# Altium schematic sheet [04] - POWER SUPPLY.SchDoc("[04] - POWER SUPPLY")
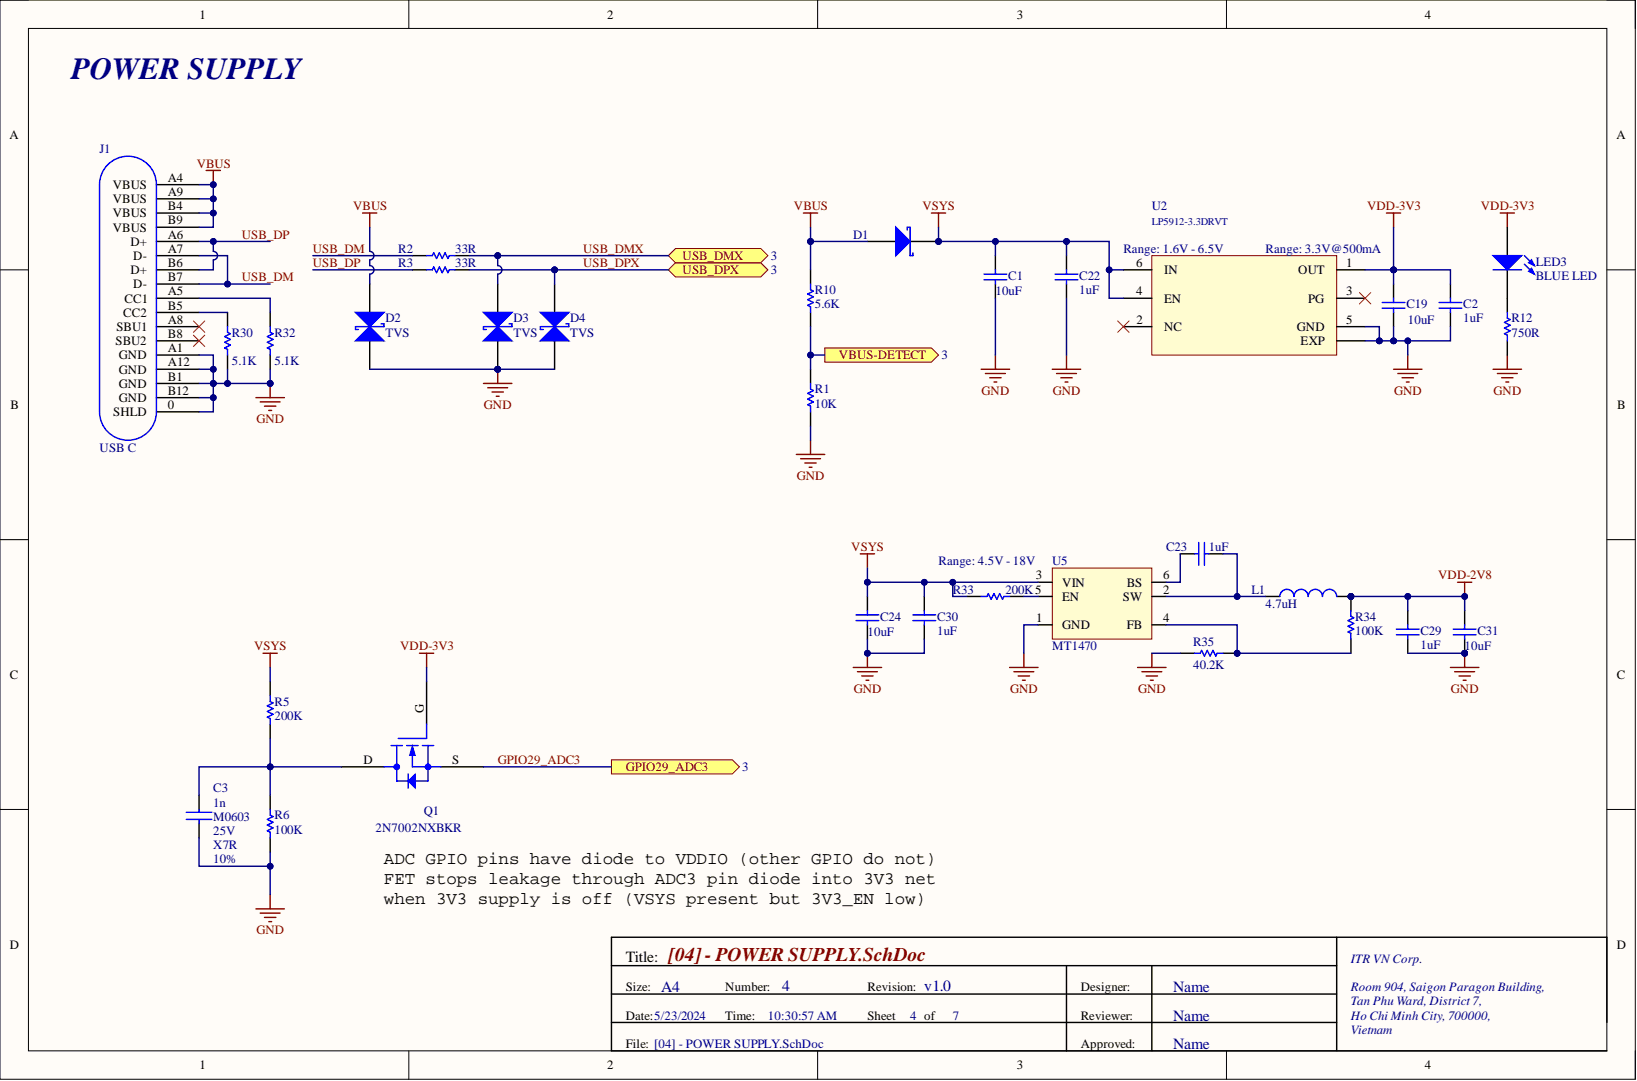
[diagram p0: [04] - POWER SUPPLY.SchDoc]

## Parts
C1  value 10uF  [CAPC2012X140_0805]  (hidden comment: Capacitor)
C2  value 1uF  [CAPC1005X55_0402]  (hidden comment: Capacitor)
C3  1n  Dielectric: X7R  Package: M0603  Tolerance: 10%  Voltage: 25V  [CAPC1005X55_0402]
C19  value 10uF  [CAPC2012X140_0805]  (hidden comment: Capacitor)
C22  value 1uF  [CAPC1005X55_0402]  (hidden comment: Capacitor)
C23  value 1uF  [CAPC1005X55_0402]  (hidden comment: Capacitor)
C24  value 10uF  [CAPC2012X140_0805]  (hidden comment: Capacitor)
C29  value 1uF  [CAPC1005X55_0402]  (hidden comment: Capacitor)
C30  value 1uF  [CAPC1005X55_0402]  (hidden comment: Capacitor)
C31  value 10uF  [CAPC2012X140_0805]  (hidden comment: Capacitor)
D1  Diode Schottky  [SS34F]  (value not drawn)
D2  TVS  [LEDSC160X80X90_2_0603]
D3  TVS  [LEDSC160X80X90_2_0603]
D4  TVS  [LEDSC160X80X90_2_0603]
J1  USB C  [USBC_16PIN]
L1  value 4.7uH  Inductance: 4.7uH  [0806]  (hidden comment: MLP2016H4R7MT0S1)
LED3  BLUE LED  [LED-0805-SideView]
Q1  2N7002NXBKR  [SOT190P250X100_3]
R1  value 10K  [RESC1005X40_0402]  (hidden comment: Resistor)
R2  value 33R  Resistance: 33R  [RESC1005X40_0402]  (hidden comment: Resistor)
R3  value 33R  Resistance: 33R  [RESC1005X40_0402]  (hidden comment: Resistor)
R5  value 200K  [RESC1005X40_0402]  (hidden comment: Resistor)
R6  value 100K  [RESC1005X40_0402]  (hidden comment: Resistor)
R10  value 5.6K  [RESC1005X40_0402]  (hidden comment: Resistor)
R12  value 750R  [RESC1005X40_0402]  (hidden comment: Resistor)
R30  value 5.1K  [RESC1005X40_0402]  (hidden comment: Resistor)
R32  value 5.1K  [RESC1005X40_0402]  (hidden comment: Resistor)
R33  value 200K  [RESC1005X40_0402]  (hidden comment: Resistor)
R34  value 100K  [RESC1005X40_0402]  (hidden comment: Resistor)
R35  value 40.2K  [RESC1005X40_0402]  (hidden comment: Resistor)
U2  LP5912-3.3DRVT  [WDFN6_2X2_P0.65]
U5  MT1470  [SOT23-6-MT1470]

## Ports
GPIO29_ADC3, USB_DMX, USB_DPX, VBUS-DETECT

## Nets
GND: C1.1 C2.1 C3.2 C19.1 C22.1 C24.1 C29.1 C30.1 C31.1 D2.1 D3.1 D4.1 J1.0(SHLD) J1.A1(GND) J1.A12(GND) J1.B1(GND) J1.B12(GND) R1.1 R6.1 R12.1 R30.1 R32.1 R35.1 U2.5(GND) U2.EXP U5.1(GND)
VBUS: D1.1 D2.2 J1.A4(VBUS) J1.A9(VBUS) J1.B4(VBUS) J1.B9(VBUS) R10.2
VDD-2V8: C29.2 C31.2 L1.2 R34.2
VDD-3V3: C2.2 C19.2 LED3.1 Q1.G U2.1(OUT)
VSYS: C1.2 C22.2 C24.2 C30.2 D1.2 R5.2 R33.2 U2.4(EN) U2.6(IN) U5.3(VIN)
GPIO29_ADC3: Q1.S ; port GPIO29_ADC3
USB_DM: J1.A7(D-) J1.B7(D-) R2.1
USB_DMX: D3.2 R2.2 ; port USB_DMX
USB_DP: J1.A6(D+) J1.B6(D+) R3.1
USB_DPX: D4.2 R3.2 ; port USB_DPX
VBUS-DETECT: R1.2 R10.1 ; port VBUS-DETECT
NetC3_1: C3.1 Q1.D R5.1 R6.2
NetC23_1: C23.1 L1.1 U5.2(SW)
NetC23_2: C23.2 U5.6(BS)
NetJ1_A5: J1.A5(CC1) R32.2
NetJ1_B5: J1.B5(CC2) R30.2
NetLED3_2: LED3.2 R12.2
NetR33_1: R33.1 U5.5(EN)
NetR34_1: R34.1 R35.2 U5.4(FB)

Not connected: J1 A8(SBU1) B8(SBU2); U2 2(NC) 3(PG)

## Library data of the parts
C1 C19 C24 C31 = Capacitor Semi: Multilayer Ceramic Capacitor, 10 uF, 16 V, ± 10%, X5R, 0805 [2012 Metric]; Manufacturer 1: Murata; Manufacturer Part Number 1: GRM21BR61C106KE15K; Material: 4241ConductorMaterial; Voltage Rating (DC): 16V; Category: Ceramic Capacitors; Component Kind: Standard; ComponentLink1Description: https://datasheet.octopart.com/GRM188R6YA106MA73D-Murata-datasheet-149523261.pdf?src-supplier=Newark; ComponentLink1URL: https://datasheet.octopart.com/GRM188R6YA106MA73D-Murata-datasheet-149523261.pdf?src-supplier=Newark; ComponentLink2Description: http://search.murata.co.jp/Ceramy/image/img/A01X/G101/ENG/GRM188R6YA106MA73-01.pdf?src-supplier=Future+Electronics; ComponentLink2URL: http://search.murata.co.jp/Ceramy/image/img/A01X/G101/ENG/GRM188R6YA106MA73-01.pdf?src-supplier=Future+Electronics; ComponentLink3Description: http://search.murata.co.jp/Ceramy/image/img/A01X/G101/ENG/GRM188R6YA106MA73-01.pdf?src-supplier=Digi-Key; ComponentLink3URL: http://search.murata.co.jp/Ceramy/image/img/A01X/G101/ENG/GRM188R6YA106MA73-01.pdf?src-supplier=Digi-Key; ComponentLink4Description: https://www.murata.com/~/media/webrenewal/support/library/catalog/products/capacitor/mlcc/c02e.ashx?la=en-us&src-supplier=Digi-Key; ComponentLink4URL: https://www.murata.com/~/media/webrenewal/support/library/catalog/products/capacitor/mlcc/c02e.ashx?la=en-us&src-supplier=Digi-Key; ComponentLink5Description: https://datasheet.octopart.com/GRM188R6YA106MA73D-Murata-datasheet-86813743.pdf?src-supplier=Future+Electronics; ComponentLink5URL: https://datasheet.octopart.com/GRM188R6YA106MA73D-Murata-datasheet-86813743.pdf?src-supplier=Future+Electronics; ComponentLink6Description: http://search.murata.co.jp/Ceramy/image/img/A01X/G101/ENG/GRM188R6YA106MA73-01.pdf?src-supplier=Mouser; ComponentLink6URL: http://search.murata.co.jp/Ceramy/image/img/A01X/G101/ENG/GRM188R6YA106MA73-01.pdf?src-supplier=Mouser; ComponentLink7Description: https://datasheet.octopart.com/GRM188R6YA106MA73D-Murata-datasheet-165579666.pdf?src-supplier=TME; ComponentLink7URL: https://datasheet.octopart.com/GRM188R6YA106MA73D-Murata-datasheet-165579666.pdf?src-supplier=TME; ComponentLink8Description: http://search.murata.co.jp/Ceramy/image/img/A01X/G101/ENG/GRM188R6YA106MA73-01.pdf?src-supplier=TTI; ComponentLink8URL: http://search.murata.co.jp/Ceramy/image/img/A01X/G101/ENG/GRM188R6YA106MA73-01.pdf?src-supplier=TTI; Library Name: Miscellaneous_Devices.SchLib; Package: 0805; Pricing 1: 1=0.18, 10=0.119, 50=0.0714, 100=0.0562, 500=0.04146, 1000=0.03433, 2500=0.03195, 5000=0.02923 (USD); RoHS: Yes; Stock 1: 1997888; Supplier 1: Digi-Key; Supplier Part Number 1: 490-6473-1-ND
  C1: ComponentLink9Description: http://www.mouser.com/ds/2/281/c02e-2905.pdf?src-supplier=Mouser; ComponentLink9URL: http://www.mouser.com/ds/2/281/c02e-2905.pdf?src-supplier=Mouser; ComponentLink10Description: http://www.farnell.com/datasheets/1955679.pdf?src-supplier=Farnell; ComponentLink10URL: http://www.farnell.com/datasheets/1955679.pdf?src-supplier=Farnell; ComponentLink11Description: http://www.farnell.com/datasheets/1955679.pdf?src-supplier=Newark; ComponentLink11URL: http://www.farnell.com/datasheets/1955679.pdf?src-supplier=Newark; ComponentLink12Description: http://psearch.en.murata.com/capacitor/product/GRM188R60J106KE47%23.pdf?src-supplier=Avnet; ComponentLink12URL: http://psearch.en.murata.com/capacitor/product/GRM188R60J106KE47%23.pdf?src-supplier=Avnet; ComponentLink13Description: http://search.murata.co.jp/Ceramy/image/img/A01X/G101/ENG/GRM188R60J106KE47-02.pdf?src-supplier=Digi-Key; ComponentLink13URL: http://search.murata.co.jp/Ceramy/image/img/A01X/G101/ENG/GRM188R60J106KE47-02.pdf?src-supplier=Digi-Key; ComponentLink14Description: http://www.farnell.com/datasheets/1955679.pdf?src-supplier=Element14; ComponentLink14URL: http://www.farnell.com/datasheets/1955679.pdf?src-supplier=Element14; ComponentLink15Description: https://datasheet.octopart.com/GRM188R60J106KE47D-Murata-datasheet-46741883.pdf?src-supplier=Element14; ComponentLink15URL: https://datasheet.octopart.com/GRM188R60J106KE47D-Murata-datasheet-46741883.pdf?src-supplier=Element14; ComponentLink16Description: https://datasheet.octopart.com/GRM188R60J106KE47D-Murata-datasheet-76796297.pdf?src-supplier=Future+Electronics; ComponentLink16URL: https://datasheet.octopart.com/GRM188R60J106KE47D-Murata-datasheet-76796297.pdf?src-supplier=Future+Electronics; ComponentLink17Description: http://www.farnell.com/datasheets/1872210.pdf?src-supplier=Farnell; ComponentLink17URL: http://www.farnell.com/datasheets/1872210.pdf?src-supplier=Farnell; ComponentLink18Description: http://www.farnell.com/datasheets/1872210.pdf?src-supplier=Newark; ComponentLink18URL: http://www.farnell.com/datasheets/1872210.pdf?src-supplier=Newark; ComponentLink19Description: https://datasheet.octopart.com/GRM188R61A106ME69D-Murata-datasheet-22122223.pdf?src-supplier=Future+Electronics; ComponentLink19URL: https://datasheet.octopart.com/GRM188R61A106ME69D-Murata-datasheet-22122223.pdf?src-supplier=Future+Electronics; ComponentLink20Description: http://search.murata.co.jp/Ceramy/image/img/A01X/G101/ENG/GRM188R61A106ME69-01.pdf?src-supplier=Digi-Key; ComponentLink20URL: http://search.murata.co.jp/Ceramy/image/img/A01X/G101/ENG/GRM188R61A106ME69-01.pdf?src-supplier=Digi-Key; ComponentLink21Description: http://www.farnell.com/datasheets/2048014.pdf?src-supplier=Element14; ComponentLink21URL: http://www.farnell.com/datasheets/2048014.pdf?src-supplier=Element14; ComponentLink22Description: https://datasheet.octopart.com/GRM188R61A106ME69D-Murata-datasheet-62317187.pdf?src-supplier=Element14; ComponentLink22URL: https://datasheet.octopart.com/GRM188R61A106ME69D-Murata-datasheet-62317187.pdf?src-supplier=Element14; ComponentLink23Description: http://www.farnell.com/datasheets/1872210.pdf?src-supplier=Element14; ComponentLink23URL: http://www.farnell.com/datasheets/1872210.pdf?src-supplier=Element14; ComponentLink24Description: https://datasheet.octopart.com/GRM188R61A106ME69D-Murata-datasheet-165579666.pdf?src-supplier=TME; ComponentLink24URL: https://datasheet.octopart.com/GRM188R61A106ME69D-Murata-datasheet-165579666.pdf?src-supplier=TME; ComponentLink25Description: https://datasheet.ciiva.com/26822/getdatasheetpartid-31687-26822939.pdf?src-supplier=Verical; ComponentLink25URL: https://datasheet.ciiva.com/26822/getdatasheetpartid-31687-26822939.pdf?src-supplier=Verical; ComponentLink26Description: https://datasheet.ciiva.com/6270/partnumbering-e-01-6270407.pdf?src-supplier=Digi-Key; ComponentLink26URL: https://datasheet.ciiva.com/6270/partnumbering-e-01-6270407.pdf?src-supplier=Digi-Key; ComponentLink27Description: https://datasheet.ciiva.com/6270/partnumbering-e-01-6270410.pdf?src-supplier=Digi-Key; ComponentLink27URL: https://datasheet.ciiva.com/6270/partnumbering-e-01-6270410.pdf?src-supplier=Digi-Key; ComponentLink28Description: https://datasheet.ciiva.com/6270/partnumbering-e-01-6270412.pdf?src-supplier=Digi-Key; ComponentLink28URL: https://datasheet.ciiva.com/6270/partnumbering-e-01-6270412.pdf?src-supplier=Digi-Key; ComponentLink29Description: https://datasheet.ciiva.com/5414/product-5414934.pdf?src-supplier=Mouser; ComponentLink29URL: https://datasheet.ciiva.com/5414/product-5414934.pdf?src-supplier=Mouser
  C19: ComponentLink9Description: http://www.farnell.com/datasheets/1872210.pdf?src-supplier=Farnell; ComponentLink9URL: http://www.farnell.com/datasheets/1872210.pdf?src-supplier=Farnell; ComponentLink10Description: http://www.mouser.com/ds/2/281/c02e-2905.pdf?src-supplier=Mouser; ComponentLink10URL: http://www.mouser.com/ds/2/281/c02e-2905.pdf?src-supplier=Mouser; ComponentLink11Description: http://www.farnell.com/datasheets/1872210.pdf?src-supplier=Newark; ComponentLink11URL: http://www.farnell.com/datasheets/1872210.pdf?src-supplier=Newark; ComponentLink12Description: https://datasheet.octopart.com/GRM188R61A106ME69D-Murata-datasheet-22122223.pdf?src-supplier=Future+Electronics; ComponentLink12URL: https://datasheet.octopart.com/GRM188R61A106ME69D-Murata-datasheet-22122223.pdf?src-supplier=Future+Electronics; ComponentLink13Description: http://search.murata.co.jp/Ceramy/image/img/A01X/G101/ENG/GRM188R61A106ME69-01.pdf?src-supplier=Digi-Key; ComponentLink13URL: http://search.murata.co.jp/Ceramy/image/img/A01X/G101/ENG/GRM188R61A106ME69-01.pdf?src-supplier=Digi-Key; ComponentLink14Description: http://www.farnell.com/datasheets/2048014.pdf?src-supplier=Element14; ComponentLink14URL: http://www.farnell.com/datasheets/2048014.pdf?src-supplier=Element14; ComponentLink15Description: https://datasheet.octopart.com/GRM188R61A106ME69D-Murata-datasheet-62317187.pdf?src-supplier=Element14; ComponentLink15URL: https://datasheet.octopart.com/GRM188R61A106ME69D-Murata-datasheet-62317187.pdf?src-supplier=Element14; ComponentLink16Description: http://www.farnell.com/datasheets/1872210.pdf?src-supplier=Element14; ComponentLink16URL: http://www.farnell.com/datasheets/1872210.pdf?src-supplier=Element14; ComponentLink17Description: https://datasheet.octopart.com/GRM188R61A106ME69D-Murata-datasheet-165579666.pdf?src-supplier=TME; ComponentLink17URL: https://datasheet.octopart.com/GRM188R61A106ME69D-Murata-datasheet-165579666.pdf?src-supplier=TME; ComponentLink18Description: https://datasheet.ciiva.com/26822/getdatasheetpartid-31687-26822939.pdf?src-supplier=Verical; ComponentLink18URL: https://datasheet.ciiva.com/26822/getdatasheetpartid-31687-26822939.pdf?src-supplier=Verical; ComponentLink19Description: https://datasheet.ciiva.com/6270/partnumbering-e-01-6270407.pdf?src-supplier=Digi-Key; ComponentLink19URL: https://datasheet.ciiva.com/6270/partnumbering-e-01-6270407.pdf?src-supplier=Digi-Key; ComponentLink20Description: https://datasheet.ciiva.com/6270/partnumbering-e-01-6270410.pdf?src-supplier=Digi-Key; ComponentLink20URL: https://datasheet.ciiva.com/6270/partnumbering-e-01-6270410.pdf?src-supplier=Digi-Key; ComponentLink21Description: https://datasheet.ciiva.com/6270/partnumbering-e-01-6270412.pdf?src-supplier=Digi-Key; ComponentLink21URL: https://datasheet.ciiva.com/6270/partnumbering-e-01-6270412.pdf?src-supplier=Digi-Key; ComponentLink22Description: https://datasheet.ciiva.com/5414/product-5414934.pdf?src-supplier=Mouser; ComponentLink22URL: https://datasheet.ciiva.com/5414/product-5414934.pdf?src-supplier=Mouser
  C24 C31: ComponentLink9Description: https://datasheet.ciiva.com/26822/getdatasheetpartid-31687-26822939.pdf?src-supplier=Verical; ComponentLink9URL: https://datasheet.ciiva.com/26822/getdatasheetpartid-31687-26822939.pdf?src-supplier=Verical; ComponentLink10Description: https://datasheet.ciiva.com/6270/partnumbering-e-01-6270407.pdf?src-supplier=Digi-Key; ComponentLink10URL: https://datasheet.ciiva.com/6270/partnumbering-e-01-6270407.pdf?src-supplier=Digi-Key; ComponentLink11Description: https://datasheet.ciiva.com/6270/partnumbering-e-01-6270410.pdf?src-supplier=Digi-Key; ComponentLink11URL: https://datasheet.ciiva.com/6270/partnumbering-e-01-6270410.pdf?src-supplier=Digi-Key; ComponentLink12Description: https://datasheet.ciiva.com/6270/partnumbering-e-01-6270412.pdf?src-supplier=Digi-Key; ComponentLink12URL: https://datasheet.ciiva.com/6270/partnumbering-e-01-6270412.pdf?src-supplier=Digi-Key; ComponentLink13Description: https://datasheet.ciiva.com/5414/product-5414934.pdf?src-supplier=Mouser; ComponentLink13URL: https://datasheet.ciiva.com/5414/product-5414934.pdf?src-supplier=Mouser
C2 C22 C23 C29 C30 = Capacitor Semi: 0402 16 V 1 uF X5R ±20% Tolerance SMT Multilayer Ceramic Capacitor; Manufacturer 1: Murata; Manufacturer Part Number 1: GRM155R61C105MA12D; Symbol status: Released; Category: Ceramic Capacitors; Component Kind: Standard; ComponentLink1Description: http://www.mouser.com/ds/2/281/c02e-2905.pdf?src-supplier=Mouser; ComponentLink1URL: http://www.mouser.com/ds/2/281/c02e-2905.pdf?src-supplier=Mouser; ComponentLink2Description: http://search.murata.co.jp/Ceramy/image/img/A01X/G101/ENG/GRM155R61C105MA12-01.pdf?src-supplier=Digi-Key; ComponentLink2URL: http://search.murata.co.jp/Ceramy/image/img/A01X/G101/ENG/GRM155R61C105MA12-01.pdf?src-supplier=Digi-Key; ComponentLink3Description: https://search.murata.co.jp/Ceramy/image/img/A01X/G101/ENG/GRM155R61C105MA12-01.pdf?src-supplier=Digi-Key; ComponentLink3URL: https://search.murata.co.jp/Ceramy/image/img/A01X/G101/ENG/GRM155R61C105MA12-01.pdf?src-supplier=Digi-Key; ComponentLink4Description: https://datasheet.octopart.com/GRM155R61C105MA12D-Murata-datasheet-22122223.pdf?src-supplier=Future+Electronics; ComponentLink4URL: https://datasheet.octopart.com/GRM155R61C105MA12D-Murata-datasheet-22122223.pdf?src-supplier=Future+Electronics; ComponentLink5Description: https://datasheet.octopart.com/GRM155R61C105MA12D-Murata-datasheet-149522996.pdf?src-supplier=Newark; ComponentLink5URL: https://datasheet.octopart.com/GRM155R61C105MA12D-Murata-datasheet-149522996.pdf?src-supplier=Newark; Library Name: Miscellaneous_Devices.SchLib; Pricing 1: 1=0.1, 10=0.031, 50=0.017, 100=0.0138, 500=0.00988, 1000=0.00776, 2500=0.00705, 5000=0.00649 (USD); RoHS: Yes; Stock 1: 217243; Supplier 1: Digi-Key; Supplier 2: LCSC.com; Supplier Part Number 1: 490-14599-1-ND; Supplier Part Number 2: C181017
C3 = CAP_MLCC: Multilayer Ceramic Capacitor, 0.001 uF, 16 V, -20, +80%, Y5V, 0402 [1005 Metric]; Manufacturer 1: Yageo; Manufacturer Part Number 1: CC0402MRX7R9BB102; Capacitance: 1nF; Voltage Rating: 50V; Case Code (Imperial): 0402; Case Code (Metric): 1005; Case/Package: 0402; Category: Ceramic Capacitors; Component Kind: Standard; ComponentLink1Description: https://datasheet.ciiva.com/176/mlccorderingcode-176352.pdf?src-supplier=Digi-Key; ComponentLink1URL: https://datasheet.ciiva.com/176/mlccorderingcode-176352.pdf?src-supplier=Digi-Key; ComponentLink2Description: https://datasheet.ciiva.com/176/mlccorderingcode-176351.pdf?src-supplier=Digi-Key; ComponentLink2URL: https://datasheet.ciiva.com/176/mlccorderingcode-176351.pdf?src-supplier=Digi-Key; ComponentLink3Description: https://datasheet.ciiva.com/176/mlccorderingcode-176353.pdf?src-supplier=Digi-Key; ComponentLink3URL: https://datasheet.ciiva.com/176/mlccorderingcode-176353.pdf?src-supplier=Digi-Key; ComponentLink4Description: https://datasheet.ciiva.com/13211/upy-gp-np0-16v-to-50v-8-13211094.pdf?src-supplier=Mouser; ComponentLink4URL: https://datasheet.ciiva.com/13211/upy-gp-np0-16v-to-50v-8-13211094.pdf?src-supplier=Mouser; Halogen Free: Halogen Free; Lead Free: Lead Free; Length: 0.039inch; Max Operating Temperature: 125°C; Min Operating Temperature: -55°C; Mount: Surface Mount; Number of Pins: 2; Package Quantity: 1; Packaging: Tape and Reel; Pricing 1: 1=0.1, 10=0.016, 50=0.0088, 100=0.0071, 500=0.0051, 1000=0.004, 2500=0.00364, 5000=0.00335 (USD); RoHS: Yes; RoHS Compliant: Yes; Series: CC; Stock 1: 100467; Supplier 1: Digi-Key; Supplier 2: LCSC.com; Supplier Part Number 1: 311-1414-1-ND; Supplier Part Number 2: C527029; Thickness: 0.022inch; Voltage Rating (DC): 50V; Width: 0.02inch
D1 = Diode Schottley: Schottky Diodes & Rectifiers Schottky Rectifier; Manufacturer Part Number 1: B140AF-13; Average Rectified Current: 1A; Max Reverse Voltage (DC): 40V; Category: Rectifier Diodes; Component Kind: Standard; ComponentLink1Description: http://www.farnell.com/datasheets/2263976.pdf?src-supplier=Farnell; ComponentLink1URL: http://www.farnell.com/datasheets/2263976.pdf?src-supplier=Farnell; ComponentLink2Description: https://www.diodes.com/assets/Datasheets/B340AF_B345AF.pdf?src-supplier=Digi-Key; ComponentLink2URL: https://www.diodes.com/assets/Datasheets/B340AF_B345AF.pdf?src-supplier=Digi-Key; ComponentLink3Description: https://www.diodes.com/assets/Datasheets/B120AF-B140AF.pdf?src-supplier=Digi-Key; ComponentLink3URL: https://www.diodes.com/assets/Datasheets/B120AF-B140AF.pdf?src-supplier=Digi-Key; Library Name: Miscellaneous_Devices.SchLib; Manufacturer 1: Diodes; Mount: Surface Mount; Packaging: Cut Tape; Pricing 1: 1=0.34, 10=0.236, 100=0.119, 500=0.09706, 1000=0.07201, 2000=0.06059, 5000=0.05792 (USD); RoHS: Yes; RoHS Compliant: Yes; Series: -; Stock 1: 9845; Supplier 1: Digi-Key; Supplier 2: LCSC.com; Supplier Part Number 1: 31-B140AF-13CT-ND; Supplier Part Number 2: C172878
D2-D4 = TVS Diode: Symbol status: Doing; Component Kind: Standard; Description: TVS Diode; Library Name: Miscellaneous_Devices.SchLib
J1 = USB type C: USB type 16 pin; Component Kind: Standard; Library Name: Miscellaneous_Devices.SchLib; Manufacturer: N/A; Manufacturer Part Number: N/A; Notices: https://www.tcac.com.tw/usb3.1/T50E7312XXXX0ET.pdf; Supplier 1: banlinhkien.vn; Supplier Part Number 1: https://banlinhkien.vn/goods-11481-usb-3-1-type-c-loai-cai-16p-han-dan.html
L1 = MLP2016H4R7MT0S1: FIXED IND 4.7UH 1.1A 200MOHM SMD; Manufacturer 1: TDK; Core Material: Ferrite; DC Resistance (DCR): 200mR; Shielding: Shielded; Symbol status: Released; Test Frequency: 2MHz; Tolerance: 20%; Case Code (Imperial): 0806; Case Code (Metric): 2016; Case/Package: 0806; Category: Fixed Inductors; Component Kind: Standard; ComponentLink1Description: https://datasheet.ciiva.com/29697/inductor-commercial-power-mlp-en-29697690.pdf?src-supplier=Digi-Key; ComponentLink1URL: https://datasheet.ciiva.com/29697/inductor-commercial-power-mlp-en-29697690.pdf?src-supplier=Digi-Key; ComponentLink2Description: https://datasheet.ciiva.com/29697/inductor-commercial-power-mlp-en-29697689.pdf?src-supplier=Digi-Key; ComponentLink2URL: https://datasheet.ciiva.com/29697/inductor-commercial-power-mlp-en-29697689.pdf?src-supplier=Digi-Key; ComponentLink3Description: https://datasheet.ciiva.com/29697/inductor-commercial-power-mlp-en-29697691.pdf?src-supplier=Digi-Key; ComponentLink3URL: https://datasheet.ciiva.com/29697/inductor-commercial-power-mlp-en-29697691.pdf?src-supplier=Digi-Key; Lead Free: Lead Free; Manufacturer Part Number 1: MLP2016H4R7MT0S1; Max Operating Temperature: 125°C; Min Operating Temperature: -40°C; Mount: Surface Mount; Packaging: Cut Tape; Pricing 1: 1=0.33, 10=0.294, 25=0.2572, 50=0.2206, 100=0.2022, 250=0.18384, 500=0.1728, 1000=0.13235 (USD); RoHS: Yes; RoHS Compliant: Yes; Series: MLP; Stock 1: 21924; Supplier 1: Digi-Key; Supplier Part Number 1: 445-15726-1-ND; Library Name: BLOOD PRESSURE.SchLib
LED3 = LED-Side: LED GREEN CLEAR SMD R/A / LED for Side looking special for LCD backlight; Manufacturer 1: Vishay Lite-On; Manufacturer Part Number 1: LTST-S220GKT; Dominant Wavelength: 569nm; Forward Current: 30mA; Forward Voltage: 2.1V; Lens Color: Colorless; Lens Style: Diffused,Colorless,Rectangular,Clear; Luminous Intensity: 10mcd; Number of Elements: 1; Power Dissipation: 100mW; Reverse Voltage: 5V; Test Current: 20mA; Viewing Angle: 130°; Wavelength: 565nm; Case Code (Imperial): 0805; Case Code (Metric): 2012; Case/Package: 0805; Category: LEDs; Color: Green; Component Kind: Standard; ComponentLink1Description: https://datasheet.ciiva.com/2110/ltst-s220gkt-2110016.pdf?src-supplier=Digi-Key; ComponentLink1URL: https://datasheet.ciiva.com/2110/ltst-s220gkt-2110016.pdf?src-supplier=Digi-Key; ComponentLink2Description: https://datasheet.ciiva.com/15118/getdatasheetpartid-221136-15118117.pdf?src-supplier=Verical; ComponentLink2URL: https://datasheet.ciiva.com/15118/getdatasheetpartid-221136-15118117.pdf?src-supplier=Verical; ComponentLink3Description: https://datasheet.ciiva.com/11775/0900766b80dc0b6e-11775442.pdf?src-supplier=Rs; ComponentLink3URL: https://datasheet.ciiva.com/11775/0900766b80dc0b6e-11775442.pdf?src-supplier=Rs; ComponentLink4Description: https://datasheet.ciiva.com/2110/ltst-s220gkt-2110017.pdf?src-supplier=Digi-Key; ComponentLink4URL: https://datasheet.ciiva.com/2110/ltst-s220gkt-2110017.pdf?src-supplier=Digi-Key; ComponentLink5Description: https://datasheet.ciiva.com/16444/ltst-s220gkt-16444773.pdf?src-supplier=Future+Electronics; ComponentLink5URL: https://datasheet.ciiva.com/16444/ltst-s220gkt-16444773.pdf?src-supplier=Future+Electronics; Depth: 0.6mm; Height: 0.6mm; Illumination Color: Green; Lead Free: Lead Free; Length: 2.1mm; Lens Transparency: Clear; Library Name: Pico Camera.SchLib; Max Operating Temperature: 85°C; Min Operating Temperature: -55°C; Mount: Surface Mount; Number of LEDs: 1; Number of Pins: 2; Package Quantity: 1; Packaging: Tape and Reel; Power Rating: 100mW; Pricing 1: 1=0.25, 10=0.134, 100=0.0624, 500=0.05756, 1000=0.0451, 2000=0.04414 (USD); Radiation Hardening: No; RoHS: Yes; RoHS Compliant: Yes; Stock 1: 274681; Supplier 1: Digi-Key; Supplier Part Number 1: 160-1218-1-ND; Width: 1mm
Q1 = MOSFET_N: Transistor Mosfet N-ch 60V 0.33A 3-PIN SOT-23 T/r; Manufacturer 1: Nexperia; Component Kind: Standard; Manufacturer Part Number 1: 2N7002NXBKR; Pricing 1: 1=0.21, 10=0.149, 100=0.0805, 500=0.06326, 1000=0.04394 (USD); RoHS: No; Stock 1: 35523; Supplier 1: Digi-Key; Supplier 2: LCSC.com; Supplier Part Number 1: 1727-8642-1-ND; Supplier Part Number 2: C551417
R1 = Res1: RES SMD 10K OHM 5% 1/10W 0402; Manufacturer 1: Panasonic; Manufacturer Part Number 1: ERJ-2GEJ103X; BOM Type: A; Max Power Dissipation: 100mW; Resistance: 10kR; Temperature Coefficient: 200ppm/°C; Voltage Rating: 50V; Case Code (Imperial): 0402; Case Code (Metric): 1005; Case/Package: 0402; Category: Chip SMD Resistors; Component Kind: Standard; ComponentLink1Description: https://datasheet.ciiva.com/11821/0900766b80f806dd-11821170.pdf?src-supplier=RSComponents; ComponentLink1URL: https://datasheet.ciiva.com/11821/0900766b80f806dd-11821170.pdf?src-supplier=RSComponents; ComponentLink2Description: https://datasheet.ciiva.com/27055/1935721-27055112.pdf?src-supplier=Jameco; ComponentLink2URL: https://datasheet.ciiva.com/27055/1935721-27055112.pdf?src-supplier=Jameco; ComponentLink3Description: https://datasheet.ciiva.com/17212/aoa0000ce1-17212101.pdf?src-supplier=Avnet+Europe; ComponentLink3URL: https://datasheet.ciiva.com/17212/aoa0000ce1-17212101.pdf?src-supplier=Avnet+Europe; ComponentLink4Description: https://datasheet.ciiva.com/46371/1678796-46371910.pdf?src-supplier=Element14; ComponentLink4URL: https://datasheet.ciiva.com/46371/1678796-46371910.pdf?src-supplier=Element14; ComponentLink5Description: https://datasheet.ciiva.com/24070/aoa0000ce1-37422-24070679.pdf?src-supplier=Mouser; ComponentLink5URL: https://datasheet.ciiva.com/24070/aoa0000ce1-37422-24070679.pdf?src-supplier=Mouser; ComponentLink6Description: https://datasheet.ciiva.com/43460/1678796-43460391.pdf?src-supplier=Farnell; ComponentLink6URL: https://datasheet.ciiva.com/43460/1678796-43460391.pdf?src-supplier=Farnell; ComponentLink7Description: https://datasheet.ciiva.com/16774/70260739-16774504.pdf?src-supplier=Allied+Electronics; ComponentLink7URL: https://datasheet.ciiva.com/16774/70260739-16774504.pdf?src-supplier=Allied+Electronics; Contact Plating: Tin; Depth: 0.5mm; Features: Automotive AEC-Q200; Height: 0.35mm; LatestRevisionDate: 17-Jul-2002; LatestRevisionNote: Re-released for DXP Platform.; Lead Free: Lead Free; Length: 1mm; Library Name: Miscellaneous Devices.IntLib; Max Operating Temperature: 155°C; Military Standard: Not; Min Operating Temperature: -55°C; Mount: Surface Mount; Number of Terminations: 2; Package Quantity: 1; PackageDescription: Axial Device, Thru-Hole; 2 Leads; 0.3 in Pin Spacing; PackageReference: AXIAL-0.3; Packaging: Cut Tape; Power Rating: 100mW; Pricing 1: 1=0.10000, 10=0.01900, 100=0.00770, 1000=0.00345, 2500=0.00299, 5000=0.00247 (USD); Published: 8-Jun-2000; Radiation Hardening: No; REACH SVHC: No SVHC; Resistor Type: Thick Film; RoHS: Yes; Signal Integrity: Res; Simulation: RESISTOR; Stock 1: 2048955; Supplier 1: Digi-Key; Supplier 2: LCSC.com; Supplier Part Number 1: P10KJCT-ND; Supplier Part Number 2: C278577; Termination: SMD/SMT; Tolerance: 5%; Voltage Rating (DC): 50V; Width: 0.02inch; Publisher: Altium Limited
R2 R3 = R_0402: SMD Chip Resistor, 33 Ohm, ± 1%, 63 mW, 0402 [1005 Metric], Thick Film, General Purpose; Manufacturer 1: Yageo; Manufacturer Part Number 1: RC0402FR-0733RL; Max Power Dissipation: 63mW; Power Rating: 62.5mW; Symbol status: Released; Temperature Coefficient: 100ppm/°C; Voltage Rating: 50V; Case Code (Imperial): 0402; Case Code (Metric): 1005; Case/Package: 0402; Category: Chip SMD Resistors; Component Kind: Standard; ComponentLink1Description: https://datasheet.ciiva.com/11819/0900766b80c66297-11819487.pdf?src-supplier=Rs; ComponentLink1URL: https://datasheet.ciiva.com/11819/0900766b80c66297-11819487.pdf?src-supplier=Rs; ComponentLink2Description: https://datasheet.ciiva.com/24070/pyu-rc0402-51-rohs-l-6-r-8721-24070077.pdf?src-supplier=Mouser; ComponentLink2URL: https://datasheet.ciiva.com/24070/pyu-rc0402-51-rohs-l-6-r-8721-24070077.pdf?src-supplier=Mouser; ComponentLink3Description: https://datasheet.ciiva.com/7849/1018594-7849967.pdf?src-supplier=Element14; ComponentLink3URL: https://datasheet.ciiva.com/7849/1018594-7849967.pdf?src-supplier=Element14; ComponentLink4Description: https://datasheet.ciiva.com/7849/1018594-7849966.pdf?src-supplier=Element14; ComponentLink4URL: https://datasheet.ciiva.com/7849/1018594-7849966.pdf?src-supplier=Element14; ComponentLink5Description: https://datasheet.ciiva.com/15189/getdatasheetpartid-329253-15189431.pdf?src-supplier=Verical; ComponentLink5URL: https://datasheet.ciiva.com/15189/getdatasheetpartid-329253-15189431.pdf?src-supplier=Verical; ComponentLink6Description: https://datasheet.ciiva.com/17070/pyu-rc0402-51-rohs-l-6-r-17070335.pdf?src-supplier=Future+Electronics; ComponentLink6URL: https://datasheet.ciiva.com/17070/pyu-rc0402-51-rohs-l-6-r-17070335.pdf?src-supplier=Future+Electronics; Construction: Rectangular; Depth: 0.5mm; Features: Moisture Resistant; Height: 0.35mm; Lead Free: Lead Free; Length: 1mm; Library Name: Miscellaneous_Devices.SchLib; Max Operating Temperature: 155°C; Military Standard: Not; Min Operating Temperature: -55°C; Number of Pins: 2; Number of Terminations: 2; Package Quantity: 10000; Packaging: Tape and Reel; Pricing 1: 1=0.1, 10=0.014, 50=0.0084, 100=0.007, 500=0.00464, 1000=0.00396, 5000=0.00282 (USD); Reach SVHC Compliant: Unknown; Resistance Tolerance: 1%; Resistor Type: Thick Film; RoHS: Yes; RoHS Compliant: Yes; Series: RC; Size Code: 0402; Stock 1: 10443232; Supplier 1: Digi-Key; Supplier 2: LCSC.com; Supplier Part Number 1: 311-33.0LRCT-ND; Supplier Part Number 2: C138002; Technology: THICK FILM; Termination: SMD/SMT; Tolerance: 1%; Voltage Rating (AC): 50V; Voltage Rating (DC): 50V; Width: 0.5mm
R5 R33 = Resistor: SMD Chip Resistor, 200 kOhm, ± 1%, 63 mW, 0402 [1005 Metric], Thick Film, General Purpose; Manufacturer 1: Yageo; Manufacturer Part Number 1: RC0402FR-07200KL; Max Power Dissipation: 63mW; Resistance: 200kR; Temperature Coefficient: 100ppm/°C; Voltage Rating: 50V; Case Code (Imperial): 0402; Case Code (Metric): 1005; Case/Package: 0402; Category: Chip SMD Resistors; Component Kind: Standard; ComponentLink1Description: https://datasheet.ciiva.com/7849/1018594-7849899.pdf?src-supplier=Element14; ComponentLink1URL: https://datasheet.ciiva.com/7849/1018594-7849899.pdf?src-supplier=Element14; ComponentLink2Description: https://datasheet.ciiva.com/7849/1018594-7849898.pdf?src-supplier=Element14; ComponentLink2URL: https://datasheet.ciiva.com/7849/1018594-7849898.pdf?src-supplier=Element14; ComponentLink3Description: https://datasheet.ciiva.com/7983/1018594-7983509.pdf?src-supplier=Element14; ComponentLink3URL: https://datasheet.ciiva.com/7983/1018594-7983509.pdf?src-supplier=Element14; ComponentLink4Description: https://datasheet.ciiva.com/2553/pyu-r-int-thick-7-2553231.pdf?src-supplier=Digi-Key; ComponentLink4URL: https://datasheet.ciiva.com/2553/pyu-r-int-thick-7-2553231.pdf?src-supplier=Digi-Key; ComponentLink5Description: https://datasheet.ciiva.com/2553/pyu-r-int-thick-7-2553232.pdf?src-supplier=Digi-Key; ComponentLink5URL: https://datasheet.ciiva.com/2553/pyu-r-int-thick-7-2553232.pdf?src-supplier=Digi-Key; ComponentLink6Description: https://datasheet.ciiva.com/2553/pyu-r-int-thick-7-2553230.pdf?src-supplier=Digi-Key; ComponentLink6URL: https://datasheet.ciiva.com/2553/pyu-r-int-thick-7-2553230.pdf?src-supplier=Digi-Key; ComponentLink7Description: https://datasheet.ciiva.com/5562/pyu-rc0402-51-rohs-l-6-r-8721-5562539.pdf?src-supplier=Mouser; ComponentLink7URL: https://datasheet.ciiva.com/5562/pyu-rc0402-51-rohs-l-6-r-8721-5562539.pdf?src-supplier=Mouser; ComponentLink8Description: https://datasheet.ciiva.com/16804/pyu-rc0402-51-rohs-l-6-r-16804406.pdf?src-supplier=Future+Electronics; ComponentLink8URL: https://datasheet.ciiva.com/16804/pyu-rc0402-51-rohs-l-6-r-16804406.pdf?src-supplier=Future+Electronics; ComponentLink9Description: https://datasheet.ciiva.com/15189/getdatasheetpartid-331152-15189383.pdf?src-supplier=Verical; ComponentLink9URL: https://datasheet.ciiva.com/15189/getdatasheetpartid-331152-15189383.pdf?src-supplier=Verical; ComponentLink10Description: https://datasheet.ciiva.com/7849/1018594-7849897.pdf?src-supplier=Element14; ComponentLink10URL: https://datasheet.ciiva.com/7849/1018594-7849897.pdf?src-supplier=Element14; ComponentLink11Description: https://datasheet.ciiva.com/7849/1018594-7849896.pdf?src-supplier=Element14; ComponentLink11URL: https://datasheet.ciiva.com/7849/1018594-7849896.pdf?src-supplier=Element14; ComponentLink12Description: https://datasheet.ciiva.com/46394/1018594-46394953.pdf?src-supplier=Element14; ComponentLink12URL: https://datasheet.ciiva.com/46394/1018594-46394953.pdf?src-supplier=Element14; ComponentLink13Description: https://datasheet.ciiva.com/2453/pyu-r-int-thick-7-2453557.pdf?src-supplier=Digi-Key; ComponentLink13URL: https://datasheet.ciiva.com/2453/pyu-r-int-thick-7-2453557.pdf?src-supplier=Digi-Key; ComponentLink14Description: https://datasheet.ciiva.com/2453/pyu-r-int-thick-7-2453558.pdf?src-supplier=Digi-Key; ComponentLink14URL: https://datasheet.ciiva.com/2453/pyu-r-int-thick-7-2453558.pdf?src-supplier=Digi-Key; ComponentLink15Description: https://datasheet.ciiva.com/2453/pyu-r-int-thick-7-2453556.pdf?src-supplier=Digi-Key; ComponentLink15URL: https://datasheet.ciiva.com/2453/pyu-r-int-thick-7-2453556.pdf?src-supplier=Digi-Key; ComponentLink16Description: https://datasheet.ciiva.com/12667/pyu-rc0402-51-rohs-l-6-r-12667911.pdf?src-supplier=Mouser; ComponentLink16URL: https://datasheet.ciiva.com/12667/pyu-rc0402-51-rohs-l-6-r-12667911.pdf?src-supplier=Mouser; ComponentLink17Description: https://datasheet.ciiva.com/15189/getdatasheetpartid-323720-15189381.pdf?src-supplier=Verical; ComponentLink17URL: https://datasheet.ciiva.com/15189/getdatasheetpartid-323720-15189381.pdf?src-supplier=Verical; ComponentLink18Description: https://datasheet.ciiva.com/16740/pyu-rc0402-51-rohs-l-6-r-16740636.pdf?src-supplier=Future+Electronics; ComponentLink18URL: https://datasheet.ciiva.com/16740/pyu-rc0402-51-rohs-l-6-r-16740636.pdf?src-supplier=Future+Electronics; Construction: Rectangular; Depth: 0.5mm; Features: Moisture Resistant; Height: 0.016inch; Lead Free: Lead Free; Length: 0.039inch; Library Name: Miscellaneous_Devices.SchLib; Max Operating Temperature: 155°C; Military Standard: Not; Min Operating Temperature: -55°C; Number of Terminations: 2; Package Quantity: 1; Packaging: Tape and Reel; Power Rating: 63mW; Pricing 1: 1=0.1, 10=0.014, 50=0.0084, 100=0.007, 500=0.00464, 1000=0.00396, 5000=0.00282 (USD); Reach SVHC Compliant: Unknown; Resistance Tolerance: 1%; Resistor Type: Thick Film; RoHS: Yes; RoHS Compliant: Yes; Series: RC; Stock 1: 1529365; Supplier 1: Digi-Key; Supplier 2: LCSC.com; Supplier Part Number 1: 311-200KLRCT-ND; Supplier Part Number 2: C114763; Technology: THICK FILM; Termination: SMD/SMT; Tolerance: 1%; Voltage Rating (DC): 50V; Width: 0.02inch; Working Voltage: 50V
R6 R34 = Resistor: SMD Chip Resistor, 100 kOhm, ± 1%, 63 mW, 0402 [1005 Metric], Thick Film, General Purpose; Manufacturer 1: Yageo; Manufacturer Part Number 1: AC0402FR-07100KL; Max Power Dissipation: 63mW; Power Rating: 62.5mW; Resistance: 100kR; Symbol status: Released; Temperature Coefficient: 100ppm/°C; Voltage Rating: 50V; Case Code (Imperial): 0402; Case Code (Metric): 1005; Case/Package: 0402; Category: Chip SMD Resistors; Component Kind: Standard; ComponentLink1Description: http://www.yageo.com/documents/recent/PYu-AC_51_RoHS_L_2.pdf?src-supplier=Mouser; ComponentLink1URL: http://www.yageo.com/documents/recent/PYu-AC_51_RoHS_L_2.pdf?src-supplier=Mouser; ComponentLink2Description: https://datasheet.octopart.com/AC0402FR-07100KL-Yageo-datasheet-166720450.pdf?src-supplier=Future+Electronics; ComponentLink2URL: https://datasheet.octopart.com/AC0402FR-07100KL-Yageo-datasheet-166720450.pdf?src-supplier=Future+Electronics; ComponentLink3Description: http://www.yageo.com/documents/recent/PYu-AC_51_RoHS_L_2_revised.pdf?src-supplier=Future+Electronics; ComponentLink3URL: http://www.yageo.com/documents/recent/PYu-AC_51_RoHS_L_2_revised.pdf?src-supplier=Future+Electronics; Features: Moisture Resistant,Automotive AEC-Q200; Height: 0.015inch; Lead Free: Lead Free; Length: 0.039inch; Library Name: Miscellaneous_Devices.SchLib; Max Operating Temperature: 155°C; Min Operating Temperature: -55°C; Number of Terminations: 2; Packaging: Tape and Reel; Pricing 1: 1=0.1, 10=0.018, 50=0.011, 100=0.009, 500=0.00606, 1000=0.00517, 5000=0.00371 (USD); RoHS: Yes; RoHS Compliant: Yes; Series: AC; Size Code: 0402; Stock 1: 1750359; Supplier 1: Digi-Key; Supplier 2: LCSC.com; Supplier Part Number 1: YAG3434CT-ND; Supplier Part Number 2: C144809; Termination: SMD/SMT; Tolerance: 1%; Width: 0.5mm
R10 = Resistor: SMD Chip Resistor, 5.6 kOhm, ± 5%, 63 mW, 0402 [1005 Metric], Thick Film, General Purpose; Manufacturer 1: Yageo; Manufacturer Part Number 1: RC0402JR-075K6L; Symbol status: Released; Category: Chip SMD Resistors; Component Kind: Standard; ComponentLink1Description: https://datasheet.ciiva.com/12577/pyu-rc0402-51-rohs-l-6-r-12577430.pdf?src-supplier=Mouser; ComponentLink1URL: https://datasheet.ciiva.com/12577/pyu-rc0402-51-rohs-l-6-r-12577430.pdf?src-supplier=Mouser; ComponentLink2Description: https://datasheet.ciiva.com/7983/1018594-7983597.pdf?src-supplier=Element14; ComponentLink2URL: https://datasheet.ciiva.com/7983/1018594-7983597.pdf?src-supplier=Element14; ComponentLink3Description: https://datasheet.ciiva.com/7850/1018594-7850232.pdf?src-supplier=Element14; ComponentLink3URL: https://datasheet.ciiva.com/7850/1018594-7850232.pdf?src-supplier=Element14; ComponentLink4Description: https://datasheet.ciiva.com/7850/1018594-7850233.pdf?src-supplier=Element14; ComponentLink4URL: https://datasheet.ciiva.com/7850/1018594-7850233.pdf?src-supplier=Element14; ComponentLink5Description: https://datasheet.ciiva.com/8892/pyu-rc0402-51-rohs-l-6-8892584.pdf?src-supplier=Avnet; ComponentLink5URL: https://datasheet.ciiva.com/8892/pyu-rc0402-51-rohs-l-6-8892584.pdf?src-supplier=Avnet; ComponentLink6Description: https://datasheet.ciiva.com/2518/pyu-r-int-thick-7-2518877.pdf?src-supplier=Digi-Key; ComponentLink6URL: https://datasheet.ciiva.com/2518/pyu-r-int-thick-7-2518877.pdf?src-supplier=Digi-Key; ComponentLink7Description: https://datasheet.ciiva.com/2518/pyu-r-int-thick-7-2518878.pdf?src-supplier=Digi-Key; ComponentLink7URL: https://datasheet.ciiva.com/2518/pyu-r-int-thick-7-2518878.pdf?src-supplier=Digi-Key; ComponentLink8Description: https://datasheet.ciiva.com/2518/pyu-r-int-thick-7-2518879.pdf?src-supplier=Digi-Key; ComponentLink8URL: https://datasheet.ciiva.com/2518/pyu-r-int-thick-7-2518879.pdf?src-supplier=Digi-Key; ComponentLink9Description: https://datasheet.ciiva.com/16746/pyu-rc0402-51-rohs-l-6-r-16746885.pdf?src-supplier=Future+Electronics; ComponentLink9URL: https://datasheet.ciiva.com/16746/pyu-rc0402-51-rohs-l-6-r-16746885.pdf?src-supplier=Future+Electronics; Library Name: Miscellaneous_Devices.SchLib; Pricing 1: 1=0.1, 10=0.018, 50=0.0098, 100=0.0079, 500=0.00502, 1000=0.00422, 5000=0.00292 (USD); RoHS: Yes; Stock 1: 322978; Supplier 1: Digi-Key; Supplier 2: LCSC.com; Supplier Part Number 1: 311-5.6KJRCT-ND; Supplier Part Number 2: C137856
R12 = Resistor: SMD Chip Resistor, 750 Ohm, ± 1%, 63 mW, 0402 [1005 Metric], Thick Film, General Purpose; Manufacturer 1: Yageo; Manufacturer Part Number 1: RC0402FR-07750RL; Symbol status: Released; Category: Chip SMD Resistors; Component Kind: Standard; ComponentLink1Description: https://datasheet.ciiva.com/12667/pyu-rc0402-51-rohs-l-6-r-12667049.pdf?src-supplier=Mouser; ComponentLink1URL: https://datasheet.ciiva.com/12667/pyu-rc0402-51-rohs-l-6-r-12667049.pdf?src-supplier=Mouser; ComponentLink2Description: https://datasheet.ciiva.com/7850/1018594-7850089.pdf?src-supplier=Element14; ComponentLink2URL: https://datasheet.ciiva.com/7850/1018594-7850089.pdf?src-supplier=Element14; ComponentLink3Description: https://datasheet.ciiva.com/7850/1018594-7850090.pdf?src-supplier=Element14; ComponentLink3URL: https://datasheet.ciiva.com/7850/1018594-7850090.pdf?src-supplier=Element14; ComponentLink4Description: https://datasheet.ciiva.com/2553/pyu-r-int-thick-7-2553236.pdf?src-supplier=Digi-Key; ComponentLink4URL: https://datasheet.ciiva.com/2553/pyu-r-int-thick-7-2553236.pdf?src-supplier=Digi-Key; ComponentLink5Description: https://datasheet.ciiva.com/2553/pyu-r-int-thick-7-2553237.pdf?src-supplier=Digi-Key; ComponentLink5URL: https://datasheet.ciiva.com/2553/pyu-r-int-thick-7-2553237.pdf?src-supplier=Digi-Key; ComponentLink6Description: https://datasheet.ciiva.com/2553/pyu-r-int-thick-7-2553238.pdf?src-supplier=Digi-Key; ComponentLink6URL: https://datasheet.ciiva.com/2553/pyu-r-int-thick-7-2553238.pdf?src-supplier=Digi-Key; ComponentLink7Description: https://datasheet.ciiva.com/17196/pyu-rc0402-51-rohs-l-6-17196158.pdf?src-supplier=Future+Electronics; ComponentLink7URL: https://datasheet.ciiva.com/17196/pyu-rc0402-51-rohs-l-6-17196158.pdf?src-supplier=Future+Electronics; Library Name: Miscellaneous_Devices.SchLib; Pricing 1: 1=0.1, 10=0.014, 50=0.0084, 100=0.007, 500=0.00464, 1000=0.00396, 5000=0.00282 (USD); RoHS: Yes; Stock 1: 707716; Supplier 1: Digi-Key; Supplier 2: LCSC.com; Supplier Part Number 1: 311-750LRCT-ND; Supplier Part Number 2: C137936
R30 R32 = Res1: RES SMD 5.1K OHM 1% 1/10W 0402; Manufacturer: Panasonic Electronic Components; Manufacturer Part Number: ERJ-2RKF5101X; Power (Watts): 0.1W, 1/10W; Temperature Coefficient: ±100ppm/°C; Category: Resistors; Component Kind: Standard; ComponentLink1Description: https://industrial.panasonic.com/cdbs/www-data/pdf/RDA0000/AOA0000C304.pdf; ComponentLink1URL: https://industrial.panasonic.com/cdbs/www-data/pdf/RDA0000/AOA0000C304.pdf; Composition: Thick Film; Failure Rate: -; Features: Automotive AEC-Q200; Height - Seated (Max): 0.016" (0.40mm); LatestRevisionDate: 17-Jul-2002; LatestRevisionNote: Re-released for DXP Platform.; Library Name: Miscellaneous Devices.IntLib; Operating Temperature: -55°C ~ 155°C; Package / Case: 0402 (1005 Metric); PackageDescription: Axial Device, Thru-Hole; 2 Leads; 0.3 in Pin Spacing; PackageReference: AXIAL-0.3; Packaging: Cut Tape (CT); Part Status: Active; Pricing 1: 1=0.1, 10=0.043, 100=0.0176, 1000=0.00791, 2500=0.00686, 5000=0.00567 (USD); Published: 8-Jun-2000; RoHS: RoHS Compliant; Signal Integrity: Res; Simulation: RESISTOR; Size / Dimension: 0.039" L x 0.020" W (1.00mm x 0.50mm); Stock 1: 720316; Supplier 1: thegioiic.com; Supplier Device Package: 0402; Supplier Part Number 1: https://thegioiic.com/products/dien-tro-5-1k-ohm-0402-1; Tolerance: ±1%; Publisher: Altium Limited
R35 = Resistor: RES 40.2K OHM 1% 1/16W 0402; Manufacturer 1: Yageo; Manufacturer Part Number 1: RC0402FR-1340K2L; Resistance: 40.2kR; Temperature Coefficient: 100ppm/°C; Case/Package: 0402; Category: Chip SMD Resistors; Component Kind: Standard; ComponentLink1Description: https://datasheet.ciiva.com/8889/pyu-rc0402-51-rohs-l-6-8889272.pdf?src-supplier=Avnet; ComponentLink1URL: https://datasheet.ciiva.com/8889/pyu-rc0402-51-rohs-l-6-8889272.pdf?src-supplier=Avnet; Contact Plating: Tin,Matte; Library Name: Miscellaneous_Devices.SchLib; Max Operating Temperature: 155°C; Min Operating Temperature: -55°C; Pricing 1: 1=0.1, 10=0.015, 50=0.0088, 100=0.0073, 500=0.00488, 1000=0.00417, 5000=0.00297, 10000=0.00261 (USD); RoHS: Yes; RoHS Compliant: Yes; Stock 1: 85928; Supplier 1: Digi-Key; Supplier Part Number 1: 13-RC0402FR-1340K2LCT-ND; Tolerance: 1%
U2 = LP5912-3.3: Linear Voltage Regulator IC Positive Fixed 1 Output 3.3V 500mA 6-SON (2x2); Manufacturer 1: Texas Instruments; DIS: DigiKey; DIS_NO: 296-44153-1-ND; Dropout Voltage: 950mV; GateName_1: G$1; Number of Regulators: 1; Quiescent Current: 30uA; SymbolName_1: LP5912; Category: Embedded Processors and Controllers; Component Kind: Standard; ComponentLink1Description: http://www.ti.com/lit/ds/symlink/lp5912.pdf?src-supplier=Farnell; ComponentLink1URL: http://www.ti.com/lit/ds/symlink/lp5912.pdf?src-supplier=Farnell; ComponentLink2Description: http://www.ti.com/general/docs/lit/getliterature.tsp?genericPartNumber=LP5912&amp;&amp;fileType=pdf&src-supplier=Element14; ComponentLink2URL: http://www.ti.com/general/docs/lit/getliterature.tsp?genericPartNumber=LP5912&amp;&amp;fileType=pdf&src-supplier=Element14; ComponentLink3Description: http://www.ti.com/lit/ds/symlink/lp5912.pdf?src-supplier=Digi-Key; ComponentLink3URL: http://www.ti.com/lit/ds/symlink/lp5912.pdf?src-supplier=Digi-Key; ComponentLink4Description: http://www.ti.com/lit/ds/symlink/lp5912.pdf?src-supplier=Newark; ComponentLink4URL: http://www.ti.com/lit/ds/symlink/lp5912.pdf?src-supplier=Newark; DeviceSetName: LP5912-3.3; Lead Free: Lead Free; Manufacturer Part Number 1: LP5912-3.3DRVT; Max Operating Temperature: 125°C; MFG_NO: LP5912-3.3DRVT; Min Operating Temperature: -40°C; Mount: Surface Mount; Number of Pins: 6; Output Current: 500mA; Output Voltage: 3.3V; Packaging: Tape and Reel; Pricing 1: 1=1.60000, 10=1.43500, 25=1.35400, 100=1.15370 (USD); RoHS: Yes; RoHS Compliant: Yes; Supplier 1: Digi-Key; Supplier Part Number 1: 296-44153-1-ND; Library Name: CRELY PRO GEN6 V1.0.SCHLIB; LibraryName: PatchPolygraphV1
U5 = MT1470: Manufacturer 1: AEROSEMI; Component Kind: Standard; Library Name: Pico Camera.SchLib; Manufacturer Part Number 1: MT1470; Supplier 1: thegioiic; Supplier Part Number 1: N/A
